# Altium schematic sheet MCU.SchDoc(MCU)
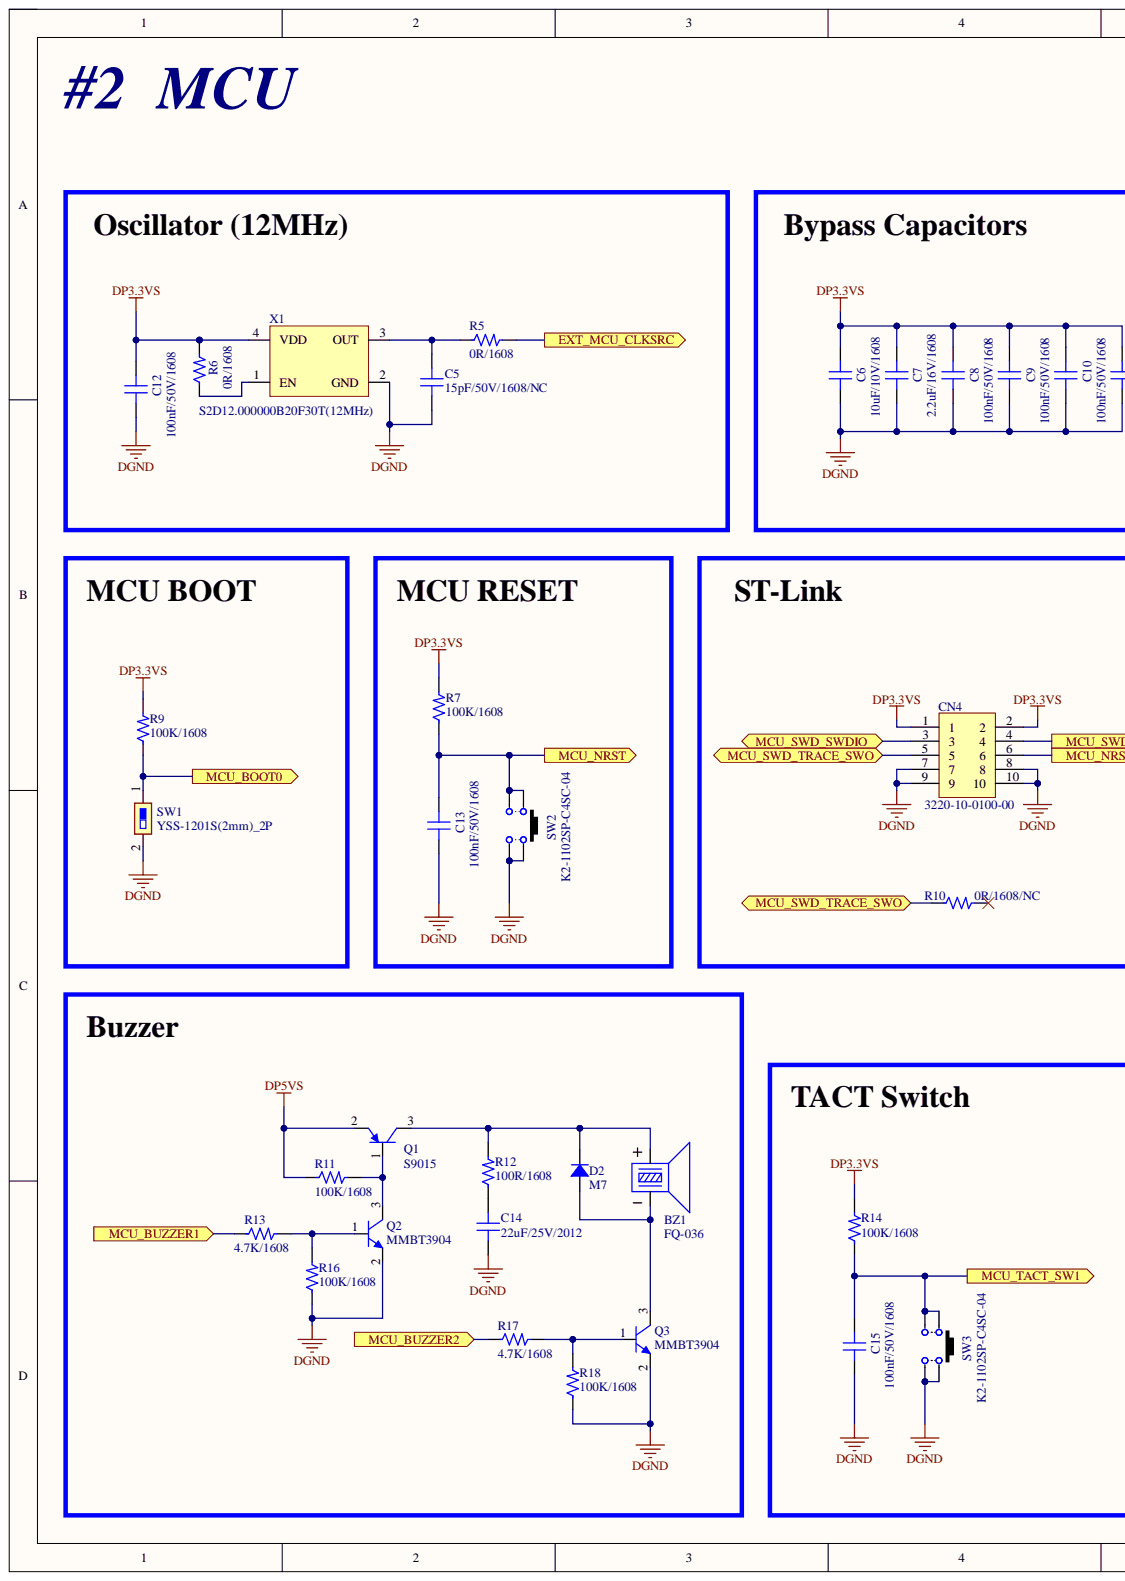
[diagram p0: MCU.SchDoc, left]
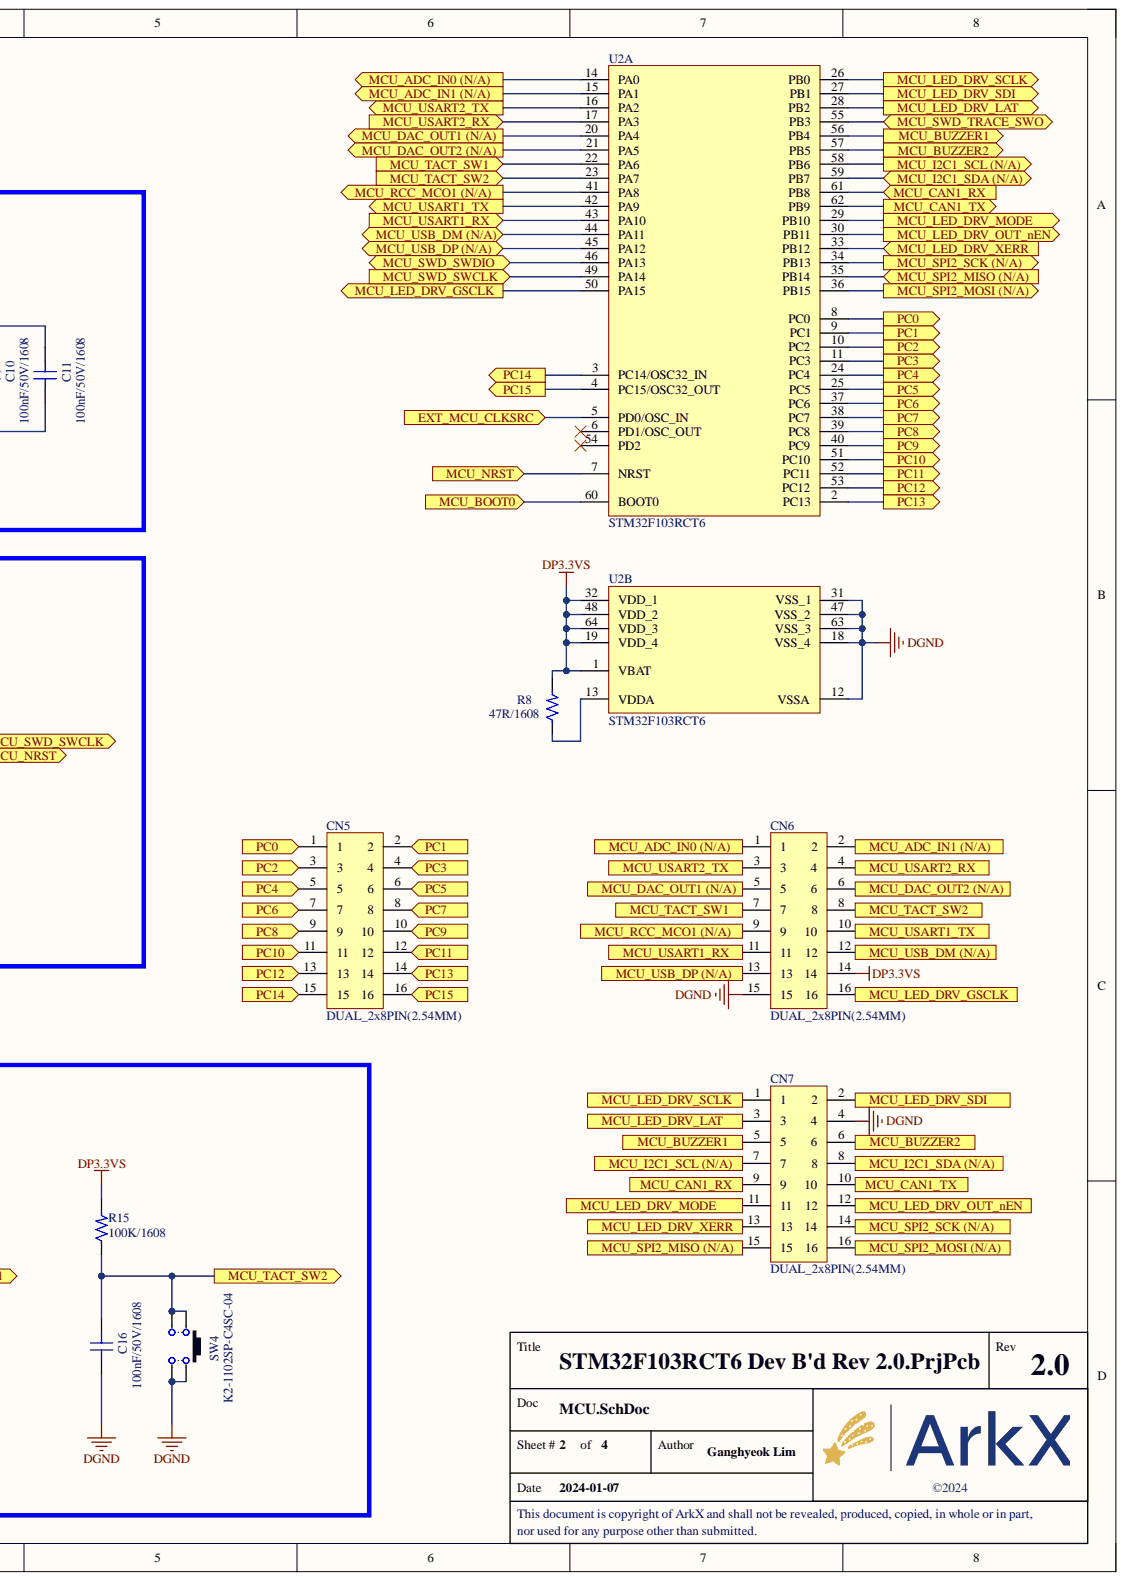
[diagram p1: MCU.SchDoc, right]

## Parts
BZ1  FQ-036  [BUZ FQ-036]
C5  15pF/50V/1608/NC  [CAP 1608 (0603_INCH)/NC]
C6  10uF/10V/1608  [CAP 1608 (0603_INCH)]
C7  2.2uF/16V/1608  [CAP 1608 (0603_INCH)]
C8  100nF/50V/1608  [CAP 1608 (0603_INCH)]
C9  100nF/50V/1608  [CAP 1608 (0603_INCH)]
C10  100nF/50V/1608  [CAP 1608 (0603_INCH)]
C11  100nF/50V/1608  [CAP 1608 (0603_INCH)]
C12  100nF/50V/1608  [CAP 1608 (0603_INCH)]
C13  100nF/50V/1608  [CAP 1608 (0603_INCH)]
C14  22uF/25V/2012  [CAP 2012 (0805_INCH)]
C15  100nF/50V/1608  [CAP 1608 (0603_INCH)]
C16  100nF/50V/1608  [CAP 1608 (0603_INCH)]
CN4  3220-10-0100-00  [CON 3220-10-0100-00]
CN5  DUAL_2x8PIN(2.54MM)  [CON PIN_HEADER_DUAL_2x8PIN(2.54MM)]
CN6  DUAL_2x8PIN(2.54MM)  [CON PIN_HEADER_DUAL_2x8PIN(2.54MM)]
CN7  DUAL_2x8PIN(2.54MM)  [CON PIN_HEADER_DUAL_2x8PIN(2.54MM)]
D2  M7  [DIO DO-214AC (SMA)]
Q1  S9015  [SOT 23-3 (DIODES INCORPORATED)]
Q2  MMBT3904  [SOT 23-3 (DIODES INCORPORATED)]
Q3  MMBT3904  [SOT 23-3 (DIODES INCORPORATED)]
R5  0R/1608  [RES 1608 (0603_inch)]
R6  0R/1608  [RES 1608 (0603_inch)]
R7  100K/1608  [RES 1608 (0603_inch)]
R8  47R/1608  [RES 1608 (0603_inch)]
R9  100K/1608  [RES 1608 (0603_inch)]
R10  0R/1608/NC  [RES 1608 (0603_inch)/NC]
R11  100K/1608  [RES 1608 (0603_inch)]
R12  100R/1608  [RES 1608 (0603_inch)]
R13  4.7K/1608  [RES 1608 (0603_inch)]
R14  100K/1608  [RES 1608 (0603_inch)]
R15  100K/1608  [RES 1608 (0603_inch)]
R16  100K/1608  [RES 1608 (0603_inch)]
R17  4.7K/1608  [RES 1608 (0603_inch)]
R18  100K/1608  [RES 1608 (0603_inch)]
SW1  YSS-1201S(2mm)_2P  [SW YSS-1201S-2mm]
SW2  K2-1102SP-C4SC-04  [SW K2-1102SP-C4SC-04]
SW3  K2-1102SP-C4SC-04  [SW K2-1102SP-C4SC-04]
SW4  K2-1102SP-C4SC-04  [SW K2-1102SP-C4SC-04]
U2  STM32F103RCT6  [LQFP-64_10x10mm (ST)]  (parts A,B)
X1  S2D12.000000B20F30T(12MHz)  [OSC SMD_2.5x2.0 (SCTF)]

## Ports
EXT_MCU_CLKSRC, MCU_ADC_IN0 (N/A), MCU_ADC_IN1 (N/A), MCU_BOOT0, MCU_BUZZER1, MCU_BUZZER2, MCU_CAN1_RX, MCU_CAN1_TX, MCU_DAC_OUT1 (N/A), MCU_DAC_OUT2 (N/A), MCU_I2C1_SCL (N/A), MCU_I2C1_SDA (N/A), MCU_LED_DRV_GSCLK, MCU_LED_DRV_LAT, MCU_LED_DRV_MODE, MCU_LED_DRV_OUT_nEN, MCU_LED_DRV_SCLK, MCU_LED_DRV_SDI, MCU_LED_DRV_XERR, MCU_NRST, MCU_RCC_MCO1 (N/A), MCU_SPI2_MISO (N/A), MCU_SPI2_MOSI (N/A), MCU_SPI2_SCK (N/A), MCU_SWD_SWCLK, MCU_SWD_SWDIO, MCU_SWD_TRACE_SWO, MCU_TACT_SW1, MCU_TACT_SW2, MCU_USART1_RX, MCU_USART1_TX, MCU_USART2_RX, MCU_USART2_TX, MCU_USB_DM (N/A), MCU_USB_DP (N/A), PC0, PC1, PC2, PC3, PC4, PC5, PC6, PC7, PC8, PC9, PC10, PC11, PC12, PC13, PC14, PC15

## Nets
DGND: C5.1 C6.1 C7.1 C8.1 C9.1 C10.1 C11.1 C12.1 C13.1 C14.1 C15.1 C16.1 CN4.7 CN4.8 CN4.9 CN4.10 CN6.15 CN7.4 Q2.2 Q3.2 R16.1 R18.1 SW1.2 SW2.3 SW2.4 SW3.3 SW3.4 SW4.3 SW4.4 U2.12(VSSA) U2.18(VSS_4) U2.31(VSS_1) U2.47(VSS_2) U2.63(VSS_3) X1.2(GND)
DP3.3VS: C6.2 C7.2 C8.2 C9.2 C10.2 C11.2 C12.2 CN4.1 CN4.2 CN6.14 R6.2 R7.2 R8.2 R9.2 R14.2 R15.2 U2.1(VBAT) U2.19(VDD_4) U2.32(VDD_1) U2.48(VDD_2) U2.64(VDD_3) X1.4(VDD)
DP5VS: Q1.2 R11.1
EXT_MCU_CLKSRC: R5.2 U2.5(PD0/OSC_IN) ; port EXT_MCU_CLKSRC
MCU_ADC_IN0 (N/A): CN6.1 U2.14(PA0) ; port MCU_ADC_IN0 (N/A)
MCU_ADC_IN1 (N/A): CN6.2 U2.15(PA1) ; port MCU_ADC_IN1 (N/A)
MCU_BOOT0: R9.1 SW1.1 U2.60(BOOT0) ; port MCU_BOOT0
MCU_BUZZER1: CN7.5 R13.1 U2.56(PB4) ; port MCU_BUZZER1
MCU_BUZZER2: CN7.6 R17.1 U2.57(PB5) ; port MCU_BUZZER2
MCU_CAN1_RX: CN7.9 U2.61(PB8) ; port MCU_CAN1_RX
MCU_CAN1_TX: CN7.10 U2.62(PB9) ; port MCU_CAN1_TX
MCU_DAC_OUT1 (N/A): CN6.5 U2.20(PA4) ; port MCU_DAC_OUT1 (N/A)
MCU_DAC_OUT2 (N/A): CN6.6 U2.21(PA5) ; port MCU_DAC_OUT2 (N/A)
MCU_I2C1_SCL (N/A): CN7.7 U2.58(PB6) ; port MCU_I2C1_SCL (N/A)
MCU_I2C1_SDA (N/A): CN7.8 U2.59(PB7) ; port MCU_I2C1_SDA (N/A)
MCU_LED_DRV_GSCLK: CN6.16 U2.50(PA15) ; port MCU_LED_DRV_GSCLK
MCU_LED_DRV_LAT: CN7.3 U2.28(PB2) ; port MCU_LED_DRV_LAT
MCU_LED_DRV_MODE: CN7.11 U2.29(PB10) ; port MCU_LED_DRV_MODE
MCU_LED_DRV_OUT_nEN: CN7.12 U2.30(PB11) ; port MCU_LED_DRV_OUT_nEN
MCU_LED_DRV_SCLK: CN7.1 U2.26(PB0) ; port MCU_LED_DRV_SCLK
MCU_LED_DRV_SDI: CN7.2 U2.27(PB1) ; port MCU_LED_DRV_SDI
MCU_LED_DRV_XERR: CN7.13 U2.33(PB12) ; port MCU_LED_DRV_XERR
MCU_NRST: C13.2 CN4.6 R7.1 SW2.1 SW2.2 U2.7(NRST) ; port MCU_NRST
MCU_RCC_MCO1 (N/A): CN6.9 U2.41(PA8) ; port MCU_RCC_MCO1 (N/A)
MCU_SPI2_MISO (N/A): CN7.15 U2.35(PB14) ; port MCU_SPI2_MISO (N/A)
MCU_SPI2_MOSI (N/A): CN7.16 U2.36(PB15) ; port MCU_SPI2_MOSI (N/A)
MCU_SPI2_SCK (N/A): CN7.14 U2.34(PB13) ; port MCU_SPI2_SCK (N/A)
MCU_SWD_SWCLK: CN4.4 U2.49(PA14) ; port MCU_SWD_SWCLK
MCU_SWD_SWDIO: CN4.3 U2.46(PA13) ; port MCU_SWD_SWDIO
MCU_SWD_TRACE_SWO: CN4.5 R10.1 U2.55(PB3) ; port MCU_SWD_TRACE_SWO
MCU_TACT_SW1: C15.2 CN6.7 R14.1 SW3.1 SW3.2 U2.22(PA6) ; port MCU_TACT_SW1
MCU_TACT_SW2: C16.2 CN6.8 R15.1 SW4.1 SW4.2 U2.23(PA7) ; port MCU_TACT_SW2
MCU_USART1_RX: CN6.11 U2.43(PA10) ; port MCU_USART1_RX
MCU_USART1_TX: CN6.10 U2.42(PA9) ; port MCU_USART1_TX
MCU_USART2_RX: CN6.4 U2.17(PA3) ; port MCU_USART2_RX
MCU_USART2_TX: CN6.3 U2.16(PA2) ; port MCU_USART2_TX
MCU_USB_DM (N/A): CN6.12 U2.44(PA11) ; port MCU_USB_DM (N/A)
MCU_USB_DP (N/A): CN6.13 U2.45(PA12) ; port MCU_USB_DP (N/A)
PC0: CN5.1 U2.8(PC0) ; port PC0
PC1: CN5.2 U2.9(PC1) ; port PC1
PC2: CN5.3 U2.10(PC2) ; port PC2
PC3: CN5.4 U2.11(PC3) ; port PC3
PC4: CN5.5 U2.24(PC4) ; port PC4
PC5: CN5.6 U2.25(PC5) ; port PC5
PC6: CN5.7 U2.37(PC6) ; port PC6
PC7: CN5.8 U2.38(PC7) ; port PC7
PC8: CN5.9 U2.39(PC8) ; port PC8
PC9: CN5.10 U2.40(PC9) ; port PC9
PC10: CN5.11 U2.51(PC10) ; port PC10
PC11: CN5.12 U2.52(PC11) ; port PC11
PC12: CN5.13 U2.53(PC12) ; port PC12
PC13: CN5.14 U2.2(PC13) ; port PC13
PC14: CN5.15 U2.3(PC14/OSC32_IN) ; port PC14
PC15: CN5.16 U2.4(PC15/OSC32_OUT) ; port PC15
NetBZ1_1: BZ1.1 D2.2 Q1.3 R12.2
NetBZ1_2: BZ1.2 D2.1 Q3.3
NetC5_2: C5.2 R5.1 X1.3(OUT)
NetC14_2: C14.2 R12.1
NetQ1_1: Q1.1 Q2.3 R11.2
NetQ2_1: Q2.1 R13.2 R16.2
NetQ3_1: Q3.1 R17.2 R18.2
NetR6_1: R6.1 X1.1(EN)
NetR8_1: R8.1 U2.13(VDDA)

Not connected: R10 2; U2 6(PD1/OSC_OUT) 54(PD2)

## Library data of the parts
BZ1 = BUZ_FQ-036: 5V Passive Buzzer / Rated Voltage: 5V / Size: 12x9mm; Component Kind: Standard; Library Name: Arkx.IntLib
C5 = CAP_NC_15pF/50V/1608: Ceramic CAP_100nF/50V/1608; Component Kind: Standard; Library Name: Arkx.IntLib
C6 = CAP_10uF/10V/1608: Ceramic CAP_10uF/10V/1608; Component Kind: Standard; JLCPCB Part Type: Basic Part; LCSC Part #: C19702; Library Name: Arkx.IntLib
C7 = CAP_2.2uF/16V/1608: Ceramic CAP_2.2uF/16V/1608; Component Kind: Standard; JLCPCB Part Type: Basic Part; LCSC Part #: C23630; Library Name: Arkx.IntLib
C8-C13 C15 C16 = CAP_100nF/50V/1608: Ceramic CAP_100nF/50V/1608; Component Kind: Standard; JLCPCB Part Type: Basic Part; LCSC Part #: C14663; Library Name: Arkx.IntLib
C14 = CAP_22uF/25V/2012: Ceramic CAP_22uF/25V/2012; Component Kind: Standard; JLCPCB Part Type: Basic Part; LCSC Part #: C45783; Library Name: Arkx.IntLib
CN4 = CON_IDC_3220-10-0100-00: CONN HEADER VERT 10POS 1.27MM; Component Kind: Standard; Library Name: Arkx.IntLib
CN5-CN7 = CON_PIN_HEADER_DUAL_2x8PIN(2.54MM): PIN_HEADER_DUAL_2x8PIN(2.54MM); Component Kind: Standard; Library Name: Arkx.IntLib
D2 = DIO_M7: 1A 5uA@1kV 1kV 1.1V@1A SMA(DO-214AC)  Diodes - General Purpose ROHS; Component Kind: Standard; JLCPCB Part Type: Basic Part; LCSC Part #: C95872; Library Name: Arkx.IntLib
Q1 = BJT_PNP_S9015: 100nA 45V 200mW 100mA 300@1mA,5V 150MHz 300mV@100mA,10mA PNP +150℃@(Tj) SOT-23(SOT-23-3)  Bipolar Transistors - BJT ROHS; Component Kind: Standard; JLCPCB Part Type: Basic Part; LCSC Part #: C2149; Library Name: Arkx.IntLib
Q2 Q3 = BJT_NPN_MMBT3904: 100nA 40V 200mW 200mA 100@10mA,1V 300MHz 300mV@50mA,5mA NPN +150℃@(Tj) SOT-23(SOT-23-3)  Bipolar Transistors - BJT ROHS; Component Kind: Standard; JLCPCB Part Type: Basic Part; LCSC Part #: C20526; Library Name: Arkx.IntLib
R5 R6 = RES_0R/1608/0.1W: Power Rating: 0.1W; Precision: 1%; Value: 0R; Component Kind: Standard; Description: RES_0R/1608/0.1W; JLCPCB Part Type: Basic Part; LCSC Part #: C21189; Size: 1608; Library Name: Arkx.IntLib
R7 R9 R11 R14-R16 R18 = RES_100K/1608/0.1W: Power Rating: 0.1W; Precision: 1%; Value: 100K; Component Kind: Standard; Description: RES_100K/1608/0.1W; JLCPCB Part Type: Basic Part; LCSC Part #: C25803; Size: 1608; Library Name: Arkx.IntLib
R8 = RES_47R/1608/0.1W: Power Rating: 0.1W; Precision: 1%; Value: 47R; Component Kind: Standard; Description: RES_47R/1608/0.1W; JLCPCB Part Type: Basic Part; LCSC Part #: C23182; Size: 1608; Library Name: Arkx.IntLib
R10 = RES_NC_0R/1608/0.1W: 0R/1608/0.1W/NC; Power Rating: 0.1W; Precision: 1%; Value: 0R; Component Kind: Standard; Size: 1608; Library Name: Arkx.IntLib
R12 = RES_100R/1608/0.1W: Power Rating: 0.1W; Precision: 1%; Value: 100R; Component Kind: Standard; Description: RES_100R/1608/0.1W; JLCPCB Part Type: Basic Part; LCSC Part #: C22775; Size: 1608; Library Name: Arkx.IntLib
R13 R17 = RES_4.7K/1608/0.1W: Power Rating: 0.1W; Precision: 1%; Value: 4.7K; Component Kind: Standard; Description: RES_4.7K/1608/0.1W; JLCPCB Part Type: Basic Part; LCSC Part #: C23162; Size: 1608; Library Name: Arkx.IntLib
SW1 = SW_YSS-1201S(2mm)_2P: SMD Slide Switch.  Rating : DC 6V/0.3A  Travel : 2MM; Component Kind: Standard; Library Name: Arkx.IntLib
SW2-SW4 = SW_K2-1102SP-C4SC-04: -25℃~+85℃ 6mm 5mm Round Button 50mA Brick nogging 6mm SPST 100MΩ 100000 250gf@±30gf 12V SMD  Tactile Switches ROHS; Component Kind: Standard; JLCPCB Part Type: Extended Part; LCSC Part #: C127509; Library Name: Arkx.IntLib
U2 = IC_MCU_STM32F103RCT6: 48KB 256K@x8bit 2V~3.6V -40℃~+85℃ ARM Cortex-M3 1@x2ch/12bit 1@x16ch/12bit Internal oscillator included  72MHz 51 LQFP-64_10x10x05P  Microcontroller Units (MCUs/MPUs/SOCs) ROHS; Component Kind: Standard; JLCPCB Part Type: Extended Part; LCSC Part #: C8323; Library Name: Arkx.IntLib
X1 = OSC_S2D12.000000B20F30T(12MHz): 3.3V~3.3V -40℃~+85℃ 20mA ±20ppm 12MHz SMD2520-4P  Oscillators ROHS; Component Kind: Standard; JLCPCB Part Type: Extended Part; LCSC Part #: C387449; Library Name: Arkx.IntLib
